# Altium schematic sheet Pre charge draft 1.SchDoc
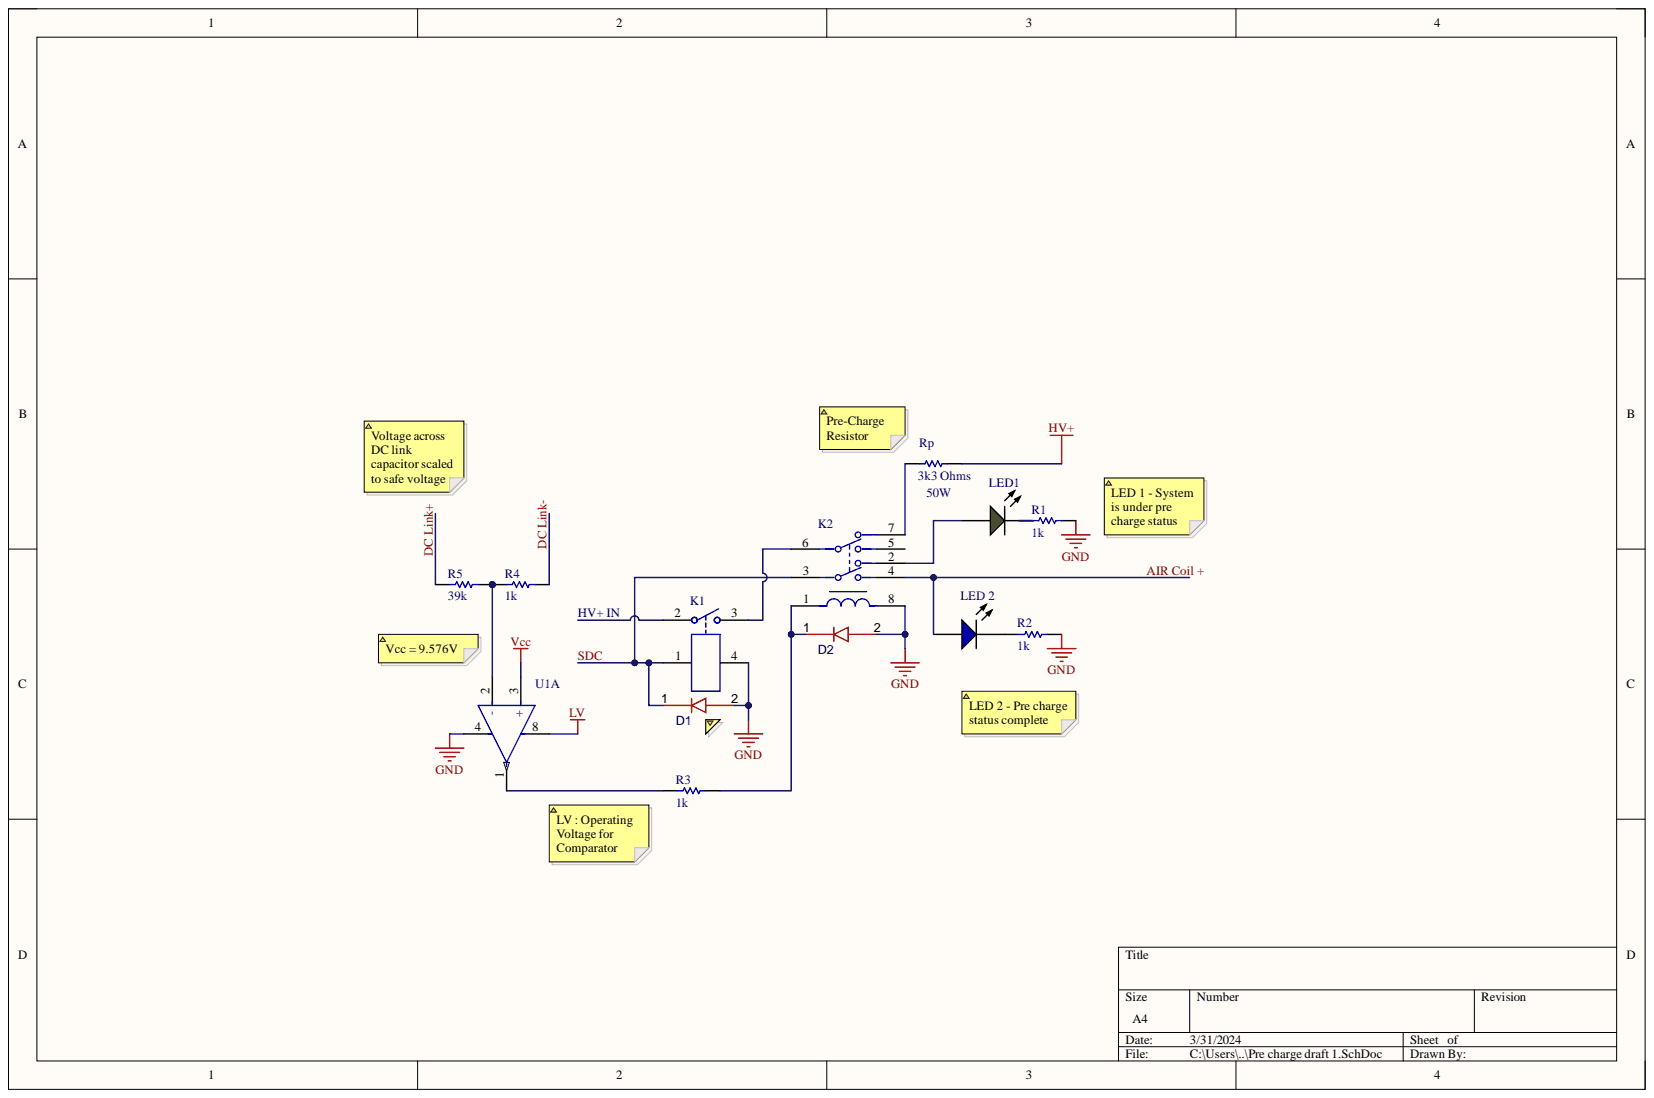
[diagram p0: Pre charge draft 1.SchDoc]

## Parts
D1  Diodes  (value not drawn)
D2  Diodes  (value not drawn)
K1  (no value)  [FJSU-JV-5S-KT_V]
K2  (no value)  [FP-G2RL-24-DC12-MFG]
LED1  (no value)  [KING-WP7113SGC_V]
LED_2  (no value)  [VISH-TLMX1100-2_V]
R1  value 1k  [RESC3116X70X50ML13T20]  (hidden comment: Resistor 1k +/-1% 1206 250 mW)
R2  value 1k  [RESC3116X70X50ML13T20]  (hidden comment: Resistor 1k +/-1% 1206 250 mW)
R3  value 1k  [RESC3116X70X50ML13T20]  (hidden comment: Resistor 1k +/-1% 1206 250 mW)
R4  value 1k  [RESC3116X70X50ML13T20]  (hidden comment: Resistor 1k +/-1% 1206 250 mW)
R5  R1: 39k  [RESC3116X70X50ML13T20]  (hidden comment: Resistor 1k +/-1% 1206 250 mW)
Rp  value 3k3 Ohms  [RESC3116X70X50ML13T20]
U1  (no value)  [FP-D0008A-MFG]  (part A)

## Nets
GND: D1.2 D2.2 K1.4 K2.8 R1.2 R2.2 U1.4
HV+: Rp.2
LV: U1.8
Vcc: U1.3(+)
AIR Coil +: K2.4 LED_2.1
DC Link+: R5.1
DC Link-: R4.1
SDC: D1.1 K1.1 K2.3
NetD2_1: D2.1 K2.1 R3.2
NetK1_3: K1.3 K2.6
NetK2_2: K2.2 LED1.1
NetK2_7: K2.7 Rp.1
NetLED1_2: LED1.2 R1.1
NetLED 2_2: LED_2.2 R2.1
NetR3_1: R3.1 U1.1
NetR4_2: R4.2 R5.2 U1.2(-)

Not connected: K1 2; K2 5

## Library data of the parts
D1 D2 = Diodes: Diode; Component Kind: Standard; Component Type: Diodes; Library Name: University of Manchester
K1 = CMP-008-00001-4: Power Relay, 5 A, 125 Ohm, -40 to 70 degc, 4-Pin THD, RoHS; Manufacturer: Fujitsu; Contact Form: SPST; Current Rating: 5A; Operate Time: 8ms; Component Kind: Standard; Datasheet URL: http://www.fujitsu.com/downloads/MICRO/fcai/relays/jv.pdf; Datasheet Version: Apr-12; Max Operating Temperature: 70°C; Min Operating Temperature: -40°C; Mounting Technology: ThroughHole; Package Description: 4-Pin Through Hole Device, Body 17.5 x 10 mm, Picth 15.24 mm; Package Reference: JV-5S-KT; Pins: 4; RoHS Compliant: True; Library Name: University of Manchester
K2 = CMP-08822-000158-1: RELAY GEN PURPOSE DPDT 8A 12V; Approvals: UL; Coil Current: 33.33mA; Coil Power: 400mW; Coil Resistance: 360R; Coil Type: Non-Latching; Max Voltage Rating (AC): 440V; Max Voltage Rating (DC): 300V; Operate Time: 15ms; Relay Type: General Purpose; Release Time: 5ms; Case/Package: DIP; Coil Voltage (DC): 12V; Component Kind: Standard; Contact Current Rating: 8A; Contact Material: Silver; Current Rating: 8A; Depth: 12.7mm; Height: 15.7mm; Lead Free: Lead Free; Length: 29mm; Library Name: Altium Content Vault; Max Current Rating: 8A; Max Operating Temperature: 85°C; Min Operating Temperature: -40°C; Mount: Through Hole; Number of Pins: 8; Package Quantity: 100; Packaging: Bulk; Power Consumption: 400mW; Power Rating: 400mW; Radiation Hardening: No; Series: G2RL; Termination: PC Pin; Throw Configuration: DPDT; Voltage Rating (AC): 440V; Voltage Rating (DC): 300V; Weight: 0.599657oz; Width: 12.7mm
LED1 = CMP-007-00032-2: The Super Bright Green Source Color Device, 2.2 V, -40 to 85 degC, 2-Pin THD, RoHS, Tape and Reel; Manufacturer: Kingbright; Forward Voltage: 2.2V; Lens Style: Clear; Luminous Intensity: 200mcd; Viewing Angle: 20degrees; Case/Package: Radial; Colour: Green; Component Kind: Standard; Datasheet URL: http://www.kingbrightusa.com/images/catalog/SPEC/WP7113SGC.pdf; Datasheet Version: Rev. 9B, 01/2015; Illumination Colour: Green; Mounting Technology: TH; Nominal Vf: 2.2V; Package Description: 2-Pin Through Hole Device, Dia 5.9 mm, Body Length 8.6 mm; Package Quantity: 1000; Package Reference: WP7113SGC; Packaging: Bulk; RoHS Compliant: True; Library Name: University of Manchester
LED_2 = CMP-007-00028-2: Blue LED, 10 mA, 3.9 V, -40 to 100 degC, 2-Pin SMD (0603), RoHS, Tape and Reel; Manufacturer: Vishay Semiconductor; Forward Current: 10mA; Forward Voltage: 3.9V; Lens Style: Diffused; Luminous Intensity: 5mcd; Power: 68mW; Viewing Angle: 160degrees; Case/Package: 0603; Colour: Blue; Component Kind: Standard; Datasheet URL: http://download.siliconexpert.com/pdfs/2016/8/7/12/43/26/667/vsh_/manual/379263299541529tlmb1100.pdf; Datasheet Version: Rev. 2.7, 03/2016; Illumination Colour: Blue; Manufacturer URL: http://www.vishay.com/; Max Operating Temperature: 100°C; Min Operating Temperature: -40°C; Mounting Technology: SM; Nominal Vf: 3.9V; Package Description: 2-Pin Surface Mount Device, Body 1.6 x 0.8 mm; Package Quantity: 3000; Package Reference: 0603; Packaging: TapeandReel; Pins: 2; RoHS Compliant: True; Library Name: University of Manchester
R1-R5 = CMP-009-00011-5: Chip Resistor, 1 KOhm, +/- 1%, 0.25 W, -55 to 155 degC, 1206 (3216 Metric); Power: 250mW; Voltage Rating: 200V; Case/Package: 1206; Component Kind: Standard; Max Operating Temperature: 155°C; Min Operating Temperature: -55°C; Mounting Technology: SM; Pins: 2; RoHS Compliant: True; Tolerance: 1%; Library Name: University of Manchester
Rp = CMP-009-00011-5: 50W; Tolerance: 1%; Voltage Rating: 200V; Case/Package: 1206; Component Kind: Standard; Max Operating Temperature: 155°C; Min Operating Temperature: -55°C; Mounting Technology: SM; Pins: 2; Power: 50W; RoHS Compliant: True; Library Name: University of Manchester
U1 = CMP-04896-000013-1: IC DUAL DIFF COMP 8-SOIC; Manufacturer: Texas Instruments; Manufacturer Part Number: LM2903DR; Input Bias Current: -0.025uA; Input Offset Voltage: 2mV; Max Junction Temp: +150°C; Max Supply Voltage: 30V; Min Supply Voltage: 2V; Nominal Supply Current: 0.8mA; Number Of Channels: 2; Output Current Per Channel: 6mA; Response Time: 1.3us; Standoff Height: 0.11mm; Ambient Temperature Range High: +125°C; Ambient Temperature Range Low: -40°C; Automotive: No; Category: IC; Component Kind: Standard; ComponentLink2Description: Datasheet; ComponentLink2URL: http://www.ti.com/lit/ds/symlink/lm2903.pdf; Device Class L1: Integrated Circuits (ICs); Device Class L2: Linear ICs; Device Class L3: Comparators; Digikey Description: IC DUAL DIFF COMP 8-SOIC; Height: 1.75mm; Ipc Land Pattern Name: SOIC127P600X175-8; Lead Free: Yes; Library Name: Altium Content Vault; Mouser Description: Analog Comparators Dual Diff Ind Temp; Octopart: https://octopart.com/lm2903dr-texas+instruments-42147; Package: D0008A; RoHS: TRUE; Temperature: -40°C to +125°C; Temperature Range High: +125°C; Temperature Range Low: -40°C
